# Altium schematic sheet COMM.SchDoc
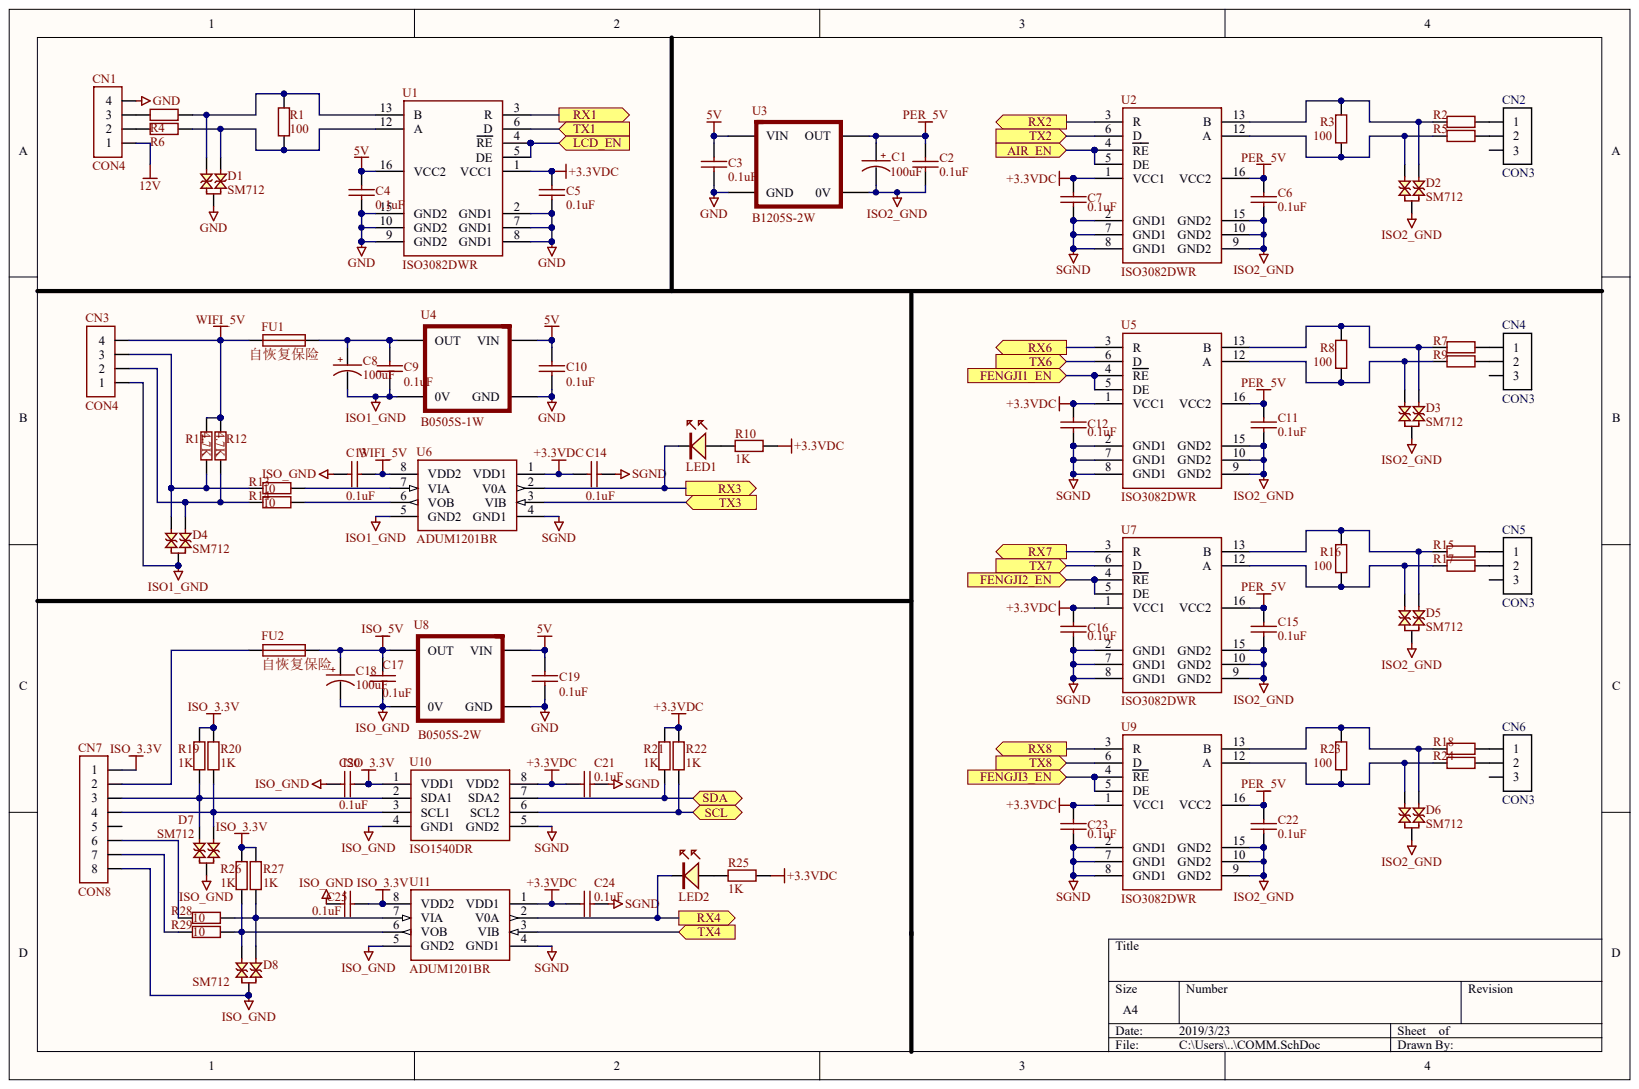
[diagram p0: COMM.SchDoc]

## Parts
C1  100uF
C2  0.1uF
C3  0.1uF
C4  0.1uF
C5  0.1uF
C6  0.1uF
C7  0.1uF
C8  100uF
C9  0.1uF
C10  0.1uF
C11  0.1uF
C12  0.1uF
C13  0.1uF
C14  0.1uF
C15  0.1uF
C16  0.1uF
C17  0.1uF
C18  100uF
C19  0.1uF
C20  0.1uF
C21  0.1uF
C22  0.1uF
C23  0.1uF
C24  0.1uF
C25  0.1uF
CN1  CON4
CN2  CON3
CN3  CON4
CN4  CON3
CN5  CON3
CN6  CON3
CN7  CON8
D1  SM712
D2  SM712
D3  SM712
D4  SM712
D5  SM712
D6  SM712
D7  SM712
D8  SM712
FU1  自恢复保险
FU2  自恢复保险
LED1  Led  (value not drawn)
LED2  Led  (value not drawn)
R1  100
R2  RES  (value not drawn)
R3  100
R4  RES  (value not drawn)
R5  RES  (value not drawn)
R6  RES  (value not drawn)
R7  RES  (value not drawn)
R8  100
R9  RES  (value not drawn)
R10  1K
R11  4.7K
R12  4.7K
R13  10
R14  10
R15  RES  (value not drawn)
R16  100
R17  RES  (value not drawn)
R18  RES  (value not drawn)
R19  1K
R20  1K
R21  1K
R22  1K
R23  100
R24  RES  (value not drawn)
R25  1K
R26  1K
R27  1K
R28  10
R29  10
U1  ISO3082DWR
U2  ISO3082DWR
U3  B1205S-2W
U4  B0505S-1W
U5  ISO3082DWR
U6  ADUM1201BR
U7  ISO3082DWR
U8  B0505S-2W
U9  ISO3082DWR
U10  ISO1540DR
U11  ADUM1201BR

## Ports
AIR_EN, FENGJI1_EN, FENGJI2_EN, FENGJI3_EN, LCD_EN, RX1, RX2, RX3, RX4, RX6, RX7, RX8, SCL, SDA, TX1, TX2, TX3, TX4, TX6, TX7, TX8

## Nets
5V: C3.2 C4.2 C10.2 C19.2 U1.16(VCC2) U3.1(VIN) U4.1(VIN) U8.1(VIN)
12V: CN1.1
+3.3VDC: C5.2 C7.2 C12.2 C14.2 C16.2 C21.2 C23.2 C24.2 R10.2 R21.2 R22.2 R25.2 U1.1(VCC1) U2.1(VCC1) U5.1(VCC1) U6.1(VDD1) U7.1(VCC1) U9.1(VCC1) U10.8(VDD2) U11.1(VDD1)
GND: C3.1 C4.1 C5.1 C10.1 C19.1 CN1.4 D1.3 U1.2(GND1) U1.7(GND1) U1.8(GND1) U1.9(GND2) U1.10(GND2) U1.15(GND2) U3.2(GND) U4.2(GND) U8.2(GND)
ISO1_GND: C8.2 C9.1 CN3.1 D4.3 U4.4(0V) U6.5(GND2)
ISO2_GND: C1.2 C2.1 C6.1 C11.1 C15.1 C22.1 D2.3 D3.3 D5.3 D6.3 U2.9(GND2) U2.10(GND2) U2.15(GND2) U3.4(0V) U5.9(GND2) U5.10(GND2) U5.15(GND2) U7.9(GND2) U7.10(GND2) U7.15(GND2) U9.9(GND2) U9.10(GND2) U9.15(GND2)
ISO_3.3V: C20.1 C25.1 CN7.1 R19.2 R20.2 R26.2 R27.2 U10.1(VDD1) U11.8(VDD2)
ISO_5V: C17.2 C18.1 FU2.1 U8.6(OUT)
ISO_GND: C13.2 C17.1 C18.2 C20.2 C25.2 CN7.8 D7.3 D8.3 U8.4(0V) U10.4(GND1) U11.5(GND2)
PER_5V: C1.1 C2.2 C6.2 C11.2 C15.2 C22.2 U2.16(VCC2) U3.6(OUT) U5.16(VCC2) U7.16(VCC2) U9.16(VCC2)
SGND: C7.1 C12.1 C14.1 C16.1 C21.1 C23.1 C24.1 U2.2(GND1) U2.7(GND1) U2.8(GND1) U5.2(GND1) U5.7(GND1) U5.8(GND1) U6.4(GND1) U7.2(GND1) U7.7(GND1) U7.8(GND1) U9.2(GND1) U9.7(GND1) U9.8(GND1) U10.5(GND2) U11.4(GND1)
WIFI_5V: C13.1 CN3.4 FU1.2 R11.2 R12.2 U6.8(VDD2)
AIR_EN: U2.4(RE) U2.5(DE) ; port AIR_EN
FENGJI1_EN: U5.4(RE) U5.5(DE) ; port FENGJI1_EN
FENGJI2_EN: U7.4(RE) U7.5(DE) ; port FENGJI2_EN
FENGJI3_EN: U9.4(RE) U9.5(DE) ; port FENGJI3_EN
LCD_EN: U1.4(RE) U1.5(DE) ; port LCD_EN
RX1: U1.3(R) ; port RX1
RX2: U2.3(R) ; port RX2
RX3: LED1.K U6.2(V0A) ; port RX3
RX4: LED2.K U11.2(V0A) ; port RX4
RX6: U5.3(R) ; port RX6
RX7: U7.3(R) ; port RX7
RX8: U9.3(R) ; port RX8
SCL: R22.1 U10.6(SCL2) ; port SCL
SDA: R21.1 U10.7(SDA2) ; port SDA
TX1: U1.6(D) ; port TX1
TX2: U2.6(D) ; port TX2
TX3: U6.3(VIB) ; port TX3
TX4: U11.3(VIB) ; port TX4
TX6: U5.6(D) ; port TX6
TX7: U7.6(D) ; port TX7
TX8: U9.6(D) ; port TX8
NetC8_1: C8.1 C9.2 FU1.1 U4.6(OUT)
NetCN1_2: CN1.2 R6.1
NetCN1_3: CN1.3 R4.1
NetCN2_1: CN2.1 R2.1
NetCN2_2: CN2.2 R5.1
NetCN3_2: CN3.2 D4.1 R12.1 R14.2
NetCN3_3: CN3.3 D4.2 R11.1 R13.2
NetCN4_1: CN4.1 R7.1
NetCN4_2: CN4.2 R9.1
NetCN5_1: CN5.1 R15.1
NetCN5_2: CN5.2 R17.1
NetCN6_1: CN6.1 R18.1
NetCN6_2: CN6.2 R24.1
NetCN7_2: CN7.2 FU2.2
NetCN7_3: CN7.3 D7.2 R19.1 U10.2(SDA1)
NetCN7_4: CN7.4 D7.1 R20.1 U10.3(SCL1)
NetCN7_6: CN7.6 R28.2
NetCN7_7: CN7.7 R29.2
NetD1_1: D1.1 R1.2 R4.2 U1.13(B)
NetD1_2: D1.2 R1.1 R6.2 U1.12(A)
NetD2_1: D2.1 R2.2 R3.2 U2.13(B)
NetD2_2: D2.2 R3.1 R5.2 U2.12(A)
NetD3_1: D3.1 R7.2 R8.2 U5.13(B)
NetD3_2: D3.2 R8.1 R9.2 U5.12(A)
NetD5_1: D5.1 R15.2 R16.2 U7.13(B)
NetD5_2: D5.2 R16.1 R17.2 U7.12(A)
NetD6_1: D6.1 R18.2 R23.2 U9.13(B)
NetD6_2: D6.2 R23.1 R24.2 U9.12(A)
NetD8_1: D8.1 R27.1 R28.1 U11.7(VIA)
NetD8_2: D8.2 R26.1 R29.1 U11.6(VOB)
NetLED1_A: LED1.A R10.1
NetLED2_A: LED2.A R25.1
NetR13_1: R13.1 U6.7(VIA)
NetR14_1: R14.1 U6.6(VOB)

Not connected: CN2 3; CN4 3; CN5 3; CN6 3; CN7 5

## Library data of the parts
C2-C7 C9-C17 C19-C25 = (no symbol name): 三星: CL10B104JB8NNNC; 风华: 0603B104J500NT
U6 U11 = (no symbol name): ADI(亚德诺): ADUM1201BRZ
C1 C8 C18 CN1-CN7 D1-D8 FU1 FU2 LED1 LED2 R1-R29 U1-U5 U7-U10 = (no symbol name)
  D1-D8: 台湾元基: SM712
